# Altium schematic sheet 2407_Microcontroleur.SchDoc
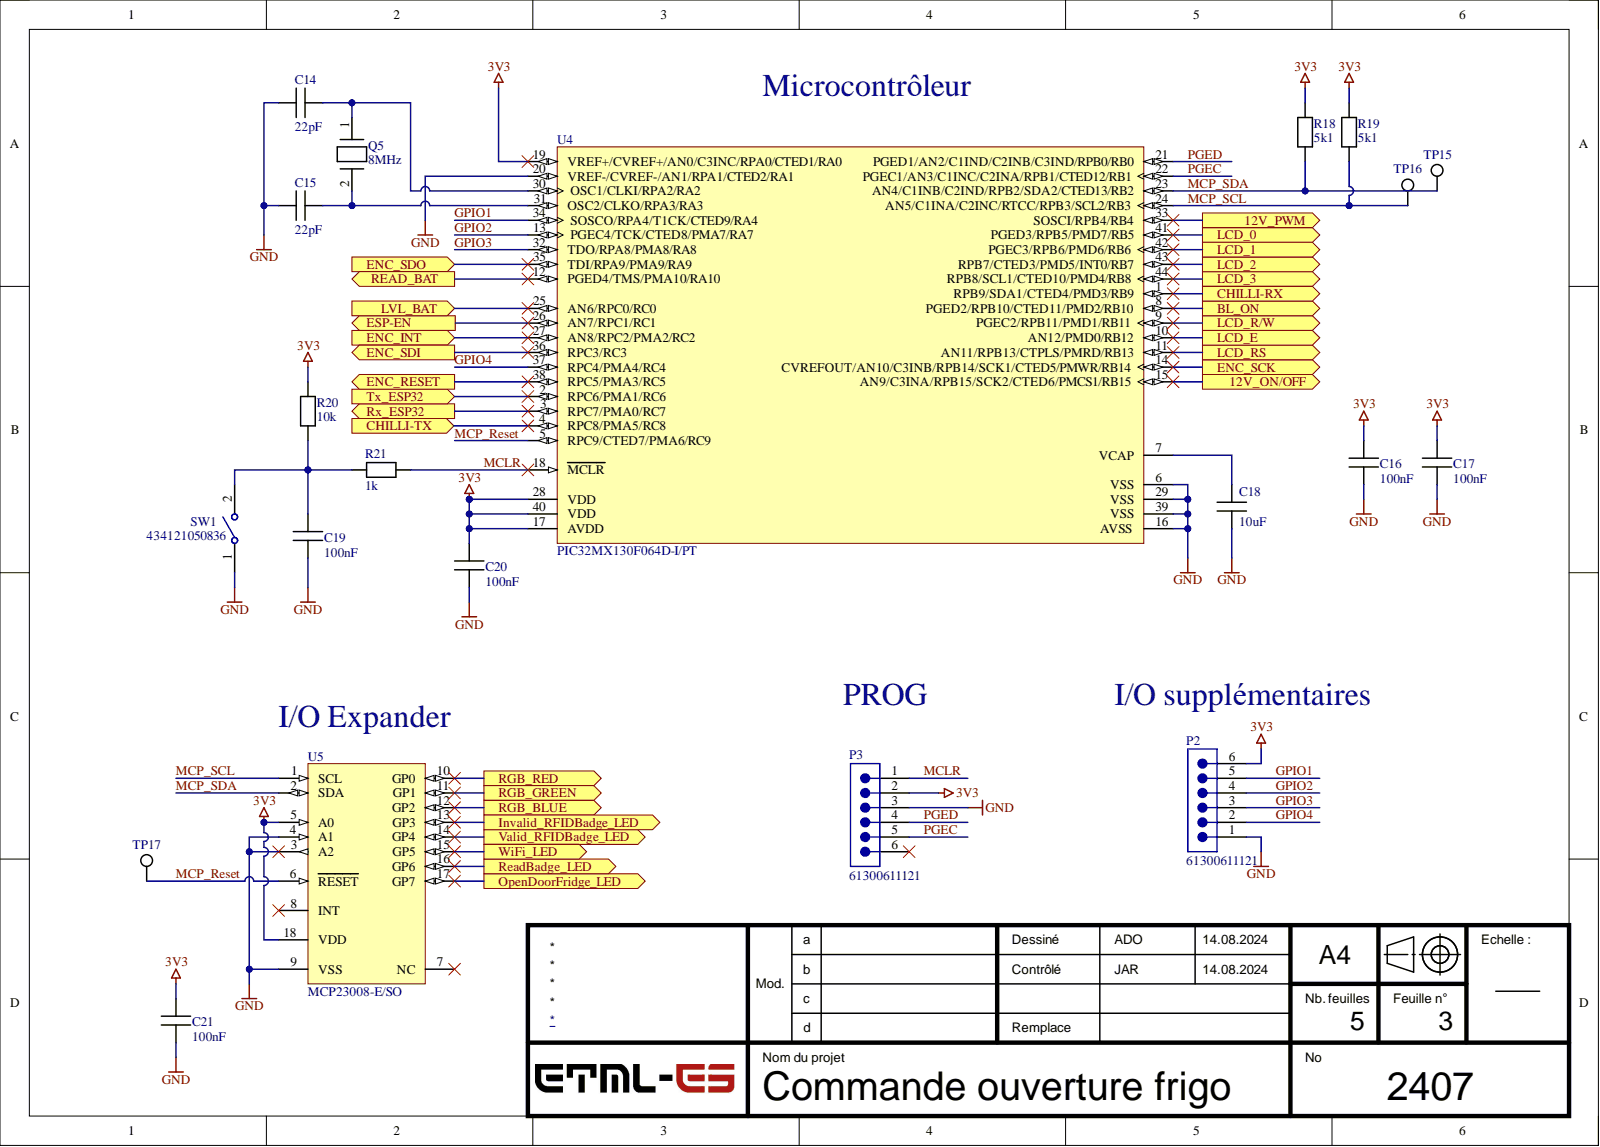
[diagram p0: 2407_Microcontroleur.SchDoc]

## Parts
C14  22pF  [CAP0805]
C15  22pF  [CAP0805]
C16  100nF  [CAP0805]
C17  100nF  [CAP0805]
C18  10uF  [CAP0805]
C19  100nF  [CAP0805]
C20  100nF  [CAP0805]
C21  100nF  [CAP0805]
P2  61300611121  [61300611121]
P3  61300611121  [61300611121]
Q5  8MHz  [ABLS-8.000MHZ-B4-T]
R18  5k1  [0805R]
R19  5k1  [0805R]
R20  10k  [0805R]
R21  1k  [0805R]
SW1  434121050836  [WS-TASV_L6W3.5H5_4341x10508x6]
TP15  5019  [KSTN-5019_V]  (value not drawn)
TP16  5019  [KSTN-5019_V]  (value not drawn)
TP17  5019  [KSTN-5019_V]  (value not drawn)
U4  PIC32MX130F064D-I/PT  [MCHP-TQFP-PT44_L]  (value not drawn)
U5  MCP23008-E/SO  [SOIC-SO18_N]

## Ports
12V_ON/OFF, 12V_PWM, BL_ON, CHILLI-RX, CHILLI-TX, ENC_INT, ENC_RESET, ENC_SCK, ENC_SDI, ENC_SDO, ESP-EN, Invalid_RFIDBadge_LED, LCD_0, LCD_1, LCD_2, LCD_3, LCD_E, LCD_R/W, LCD_RS, LVL_BAT, OpenDoorFridge_LED, READ_BAT, ReadBadge_LED, RGB_BLUE, RGB_GREEN, RGB_RED, Rx_ESP32, Tx_ESP32, Valid_RFIDBadge_LED, WiFi_LED

## Nets
3V3: C16.2 C17.2 C20.2 C21.2 P2.6 P3.2 R18.2 R19.2 R20.2 U4.17(AVDD) U4.19(VREF+/CVREF+/AN0/C3INC/RPA0/CTED1/RA0) U4.28(VDD) U4.40(VDD) U5.5(A0) U5.18(VDD)
GND: C14.1 C15.1 C16.1 C17.1 C18.1 C19.1 C20.1 C21.1 P2.1 P3.3 SW1.1 U4.6(VSS) U4.16(AVSS) U4.20(VREF-/CVREF-/AN1/RPA1/CTED2/RA1) U4.29(VSS) U4.39(VSS) U5.3(A2) U5.4(A1) U5.9(VSS)
12V_ON/OFF: U4.15(AN9/C3INA/RPB15/SCK2/CTED6/PMCS1/RB15) ; port 12V_ON/OFF
12V_PWM: U4.33(SOSCI/RPB4/RB4) ; port 12V_PWM
BL_ON: U4.8(PGED2/RPB10/CTED11/PMD2/RB10) ; port BL_ON
CHILLI-RX: U4.1(RPB9/SDA1/CTED4/PMD3/RB9) ; port CHILLI-RX
CHILLI-TX: U4.4(RPC8/PMA5/RC8) ; port CHILLI-TX
ENC_INT: U4.27(AN8/RPC2/PMA2/RC2) ; port ENC_INT
ENC_RESET: U4.38(RPC5/PMA3/RC5) ; port ENC_RESET
ENC_SCK: U4.14(CVREFOUT/AN10/C3INB/RPB14/SCK1/CTED5/PMWR/RB14) ; port ENC_SCK
ENC_SDI: U4.36(RPC3/RC3) ; port ENC_SDI
ENC_SDO: U4.35(TDI/RPA9/PMA9/RA9) ; port ENC_SDO
ESP-EN: U4.26(AN7/RPC1/RC1) ; port ESP-EN
GPIO1: P2.5 U4.34(SOSCO/RPA4/T1CK/CTED9/RA4)
GPIO2: P2.4 U4.13(PGEC4/TCK/CTED8/PMA7/RA7)
GPIO3: P2.3 U4.32(TDO/RPA8/PMA8/RA8)
GPIO4: P2.2 U4.37(RPC4/PMA4/RC4)
Invalid_RFIDBadge_LED: U5.13(GP3) ; port Invalid_RFIDBadge_LED
LCD_0: U4.41(PGED3/RPB5/PMD7/RB5) ; port LCD_0
LCD_1: U4.42(PGEC3/RPB6/PMD6/RB6) ; port LCD_1
LCD_2: U4.43(RPB7/CTED3/PMD5/INT0/RB7) ; port LCD_2
LCD_3: U4.44(RPB8/SCL1/CTED10/PMD4/RB8) ; port LCD_3
LCD_E: U4.10(AN12/PMD0/RB12) ; port LCD_E
LCD_R/W: U4.9(PGEC2/RPB11/PMD1/RB11) ; port LCD_R/W
LCD_RS: U4.11(AN11/RPB13/CTPLS/PMRD/RB13) ; port LCD_RS
LVL_BAT: U4.25(AN6/RPC0/RC0) ; port LVL_BAT
MCLR: P3.1 R21.1 U4.18(MCLR)
MCP_Reset: TP17.1 U4.5(RPC9/CTED7/PMA6/RC9) U5.6(RESET)
MCP_SCL: R19.1 TP16.1 U4.24(AN5/C1INA/C2INC/RTCC/RPB3/SCL2/RB3) U5.1(SCL)
MCP_SDA: R18.1 TP15.1 U4.23(AN4/C1INB/C2IND/RPB2/SDA2/CTED13/RB2) U5.2(SDA)
OpenDoorFridge_LED: U5.17(GP7) ; port OpenDoorFridge_LED
PGEC: P3.5 U4.22(PGEC1/AN3/C1INC/C2INA/RPB1/CTED12/RB1)
PGED: P3.4 U4.21(PGED1/AN2/C1IND/C2INB/C3IND/RPB0/RB0)
READ_BAT: U4.12(PGED4/TMS/PMA10/RA10) ; port READ_BAT
ReadBadge_LED: U5.16(GP6) ; port ReadBadge_LED
RGB_BLUE: U5.12(GP2) ; port RGB_BLUE
RGB_GREEN: U5.11(GP1) ; port RGB_GREEN
RGB_RED: U5.10(GP0) ; port RGB_RED
Rx_ESP32: U4.3(RPC7/PMA0/RC7) ; port Rx_ESP32
Tx_ESP32: U4.2(RPC6/PMA1/RC6) ; port Tx_ESP32
Valid_RFIDBadge_LED: U5.14(GP4) ; port Valid_RFIDBadge_LED
WiFi_LED: U5.15(GP5) ; port WiFi_LED
NetC14_2: C14.2 Q5.1 U4.30(OSC1/CLKI/RPA2/RA2)
NetC15_2: C15.2 Q5.2 U4.31(OSC2/CLKO/RPA3/RA3)
NetC18_2: C18.2 U4.7(VCAP)
NetC19_2: C19.2 R20.1 R21.2 SW1.2

Not connected: P3 6; U5 7(NC) 8(INT)

## Library data of the parts
P2 P3 = CMP-1502-01070-3: NexarPartId: 20084590; Connector Type: Male Pin; Contact Resistance: 20mΩ; Current Rating: 3A; Gender: Male; Number of Contacts: 6; Operating Supply Voltage: 250V; Pitch: 2.54mm; Color: Black; Component Kind: Standard; Contact Gender: Male; Contact Material: Copper; Contact Mating Length: 5.9944mm; Contact Plating: Gold; Height: 8.89mm; Housing Material: PBT; Lead Free: Lead Free; Lead Pitch: 2.54mm; Library Name: Altium Content Vault; Max Operating Temperature: 105°C; Min Operating Temperature: -40°C; Mount: Through Hole; Number of Pins: 6; Number of Positions: 6; Number of Rows: 1; Orientation: Vertical; Packaging: Bulk; REACH SVHC: Unknown; RoHS: Compliant; Termination: Through Hole; Voltage Rating: 250V
Q5 = ABLS-8.000MHZ-B4-T: Component Kind: Standard; Library Name: 2407_CommandeOuvertureFrigo.SchLib
R18 R19 = 5k1R: Component Kind: Standard; Library Name: 2407_CommandeOuvertureFrigo.SchLib
R20 = 10kR: Component Kind: Standard; Library Name: 2407_CommandeOuvertureFrigo.SchLib
R21 = 1kR: Component Kind: Standard; Library Name: 2407_CommandeOuvertureFrigo.SchLib
SW1 = CMP-1459-00008-2: NexarPartId: 57114500; Actuator Material: Liquid Crystal Polymer, Polymer; Current Rating: 50mA; Illumination Type: Non-Illuminated; Insulation Resistance: 100MΩ; Mechanical Life: 50kcycles; Switch Function: Off-Mom; Switch Travel: 250µm; Throw Configuration: SPST; Voltage Rating: 12V; Component Kind: Standard; Contact Plating: Silver; Height: 5mm; Library Name: Altium Content Vault; Max Operating Temperature: 85°C; Min Operating Temperature: -40°C; Packaging: Tape and Reel; RoHS: Compliant; Termination: SMD/SMT
TP15-TP17 = CMP-1672-00008-1: Test Point, 1 Position SMD, RoHS, Tape and Reel; Component Kind: Standard; ComponentLink1Description: Manufacturer URL; ComponentLink1URL: http://www.keyelco.com/; ComponentLink2Description: Datasheet; ComponentLink2URL: http://www.keyelco.com/product-pdf.cfm?p=1357; Contact Plating: Silver; DatasheetVersion: 03/2014; Library Name: Altium Content Vault; Mount: Surface Mount; Mounting Technology: Surface Mount; Package Quantity: 1000; PackageDescription: 1-Pin Surface Mount Device, Body 3.81 x 2.03 mm; PackageReference: 5019; Packaging: Tape and Reel; Pins: 1; RoHSCompliant: True
U4 = CMP-0048-00493-1: 32-bit Microcontroller with Audio and Graphics Interface, USB and Advanced Analog, 40 MHz, 34 I/O, PMP, -40 to 85 degC, 44-pin TQFP (PT44), Tray; Manufacturer: Microchip; Component Kind: Standard; ComponentLink1Description: Manufacturer URL; ComponentLink1URL: http://www.microchip.com; ComponentLink2Description: Datasheet; ComponentLink2URL: http://ww1.microchip.com/downloads/en/DeviceDoc/61168E.pdf; ComponentLink3Description: Package Specification; ComponentLink3URL: http://www.microchip.com/stellent/groups/techpub_sg/documents/packagingspec/en012702.pdf; ComponentLink4Description: Product URL; ComponentLink4URL: http://www.microchip.com/PIC32MX130F064D; DatasheetVersion: Rev. E; Library Name: Altium Content Vault; Mounting Technology: Surface Mount; PackageDescription: 44-Lead Plastic Thin Quad Flatpack, 10 x 10 mm Body, 0.8 mm Pitch; PackageReference: TQFP-PT44; PackageVersion: C04-076B; PartNumber: PIC32MX130F064D-I/PT
U5 = CMP-0186-00050-2: 8-Bit I/O Expander with Serial Interface, 18-Pin SOIC, Extended Temperature; Code_JEDEC: MS-013; Component Kind: Standard; ComponentLink1Description: Manufacturer URL; ComponentLink1URL: http://www.microchip.com/; ComponentLink2Description: Datasheet; ComponentLink2URL: http://ww1.microchip.com/downloads/en/DeviceDoc/21919e.pdf; ComponentLink3Description: Package Specification; ComponentLink3URL: http://www.microchip.com/stellent/groups/techpub_sg/documents/packagingspec/en012702.pdf; DatasheetVersion: revE, Aug-2007; Library Name: Altium Content Vault; PackageDescription: 18-Lead Plastic Small Outline (SO) - Wide 7.50mm Body [SOIC]; PackageReference: SOIC-SO18; PackageVersion: revBB, Aug-2009; RevisionNote: URLs added.
C14 C15 = 22pF: Component Kind: Standard; Library Name: 2407_CommandeOuvertureFrigo.SchLib
C16 C17 C19-C21 = 100nF: Component Kind: Standard; Library Name: 2407_CommandeOuvertureFrigo.SchLib
C18 = 10uF: Component Kind: Standard; Library Name: 2407_CommandeOuvertureFrigo.SchLib
